# Altium schematic sheet acroiq_basic.SchDoc
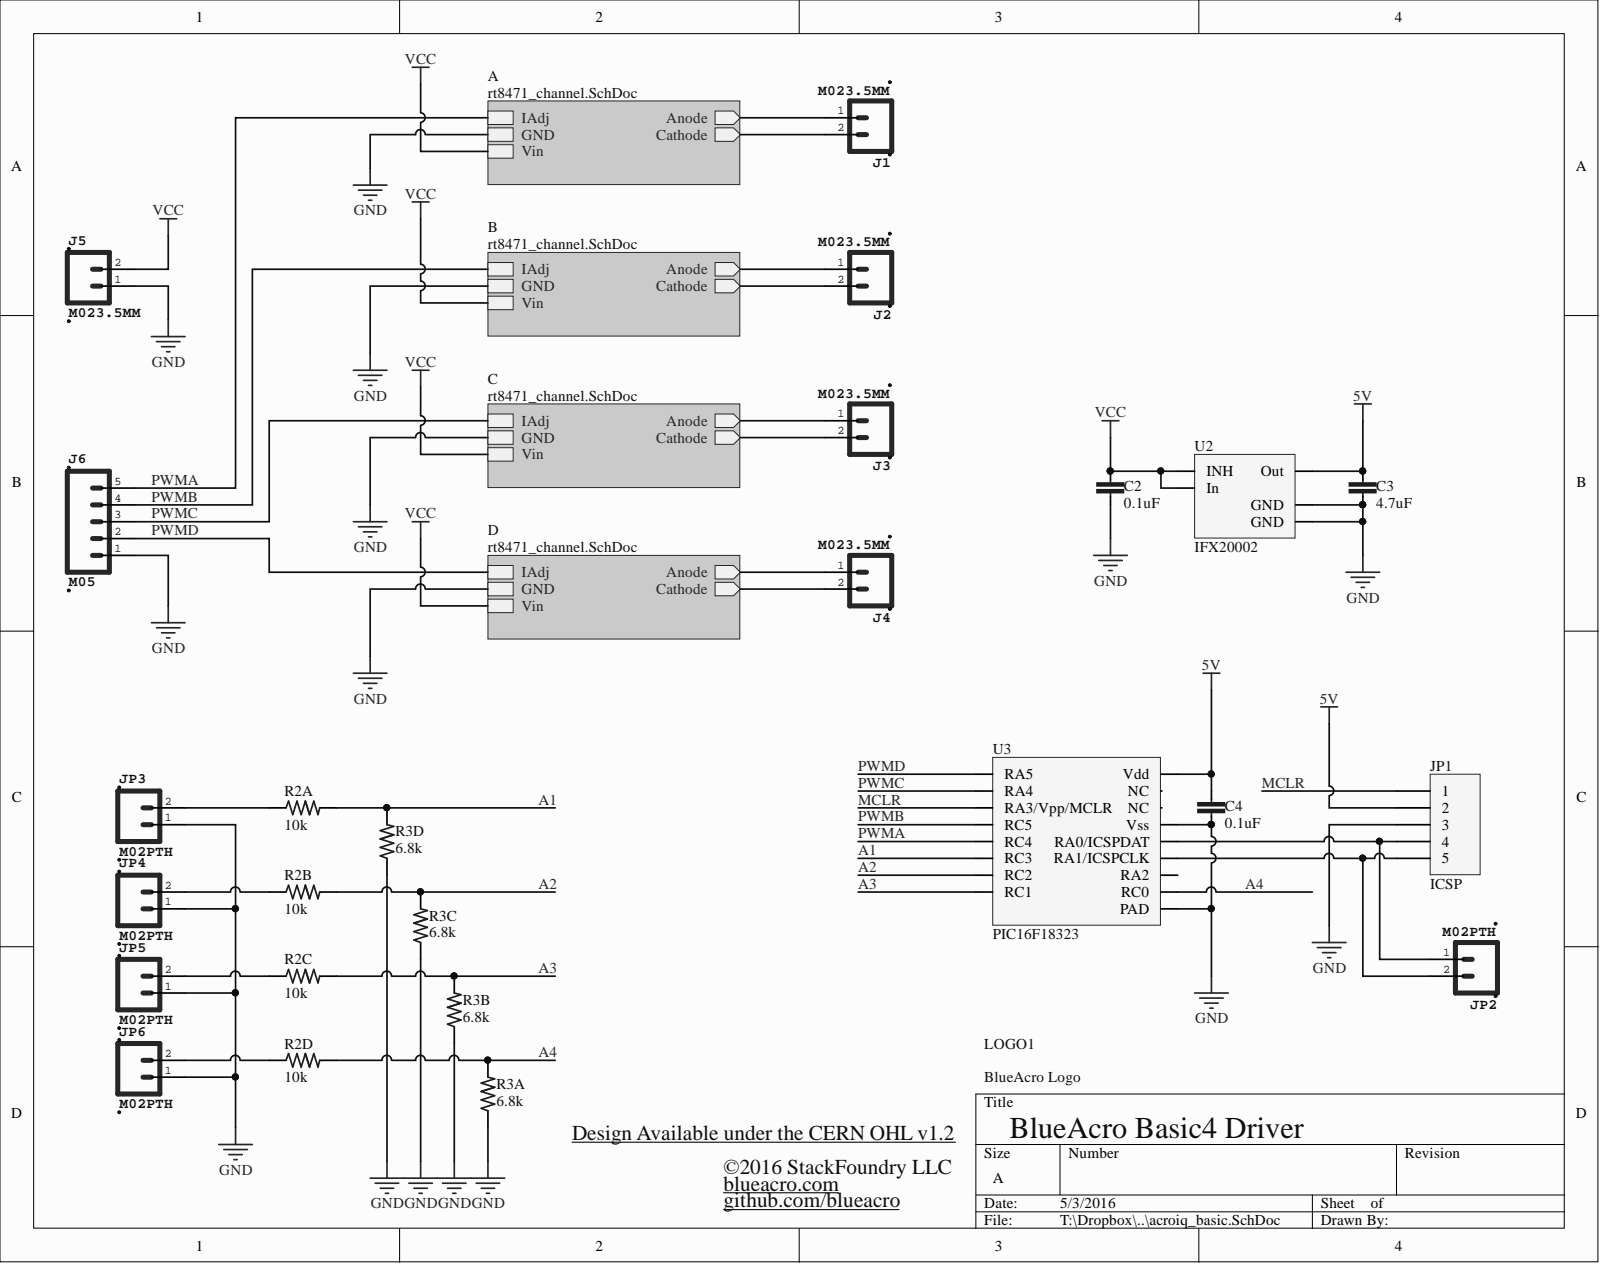
[diagram p0: acroiq_basic.SchDoc]

## Parts
C2  0.1uF  [C0603]
C3  4.7uF  [C0603]
C4  0.1uF  [C0603]
J1  M023.5MM  [SCREWTERMINAL-3.5MM-2]
J2  M023.5MM  [SCREWTERMINAL-3.5MM-2]
J3  M023.5MM  [SCREWTERMINAL-3.5MM-2]
J4  M023.5MM  [SCREWTERMINAL-3.5MM-2]
J5  M023.5MM  [SCREWTERMINAL-3.5MM-2]
J6  M05  [1X05]
JP1  ICSP  [TC2030-MCP-NL]
JP2  M02PTH  [1X02]
JP3  M02PTH  [1X02]
JP4  M02PTH  [1X02]
JP5  M02PTH  [1X02]
JP6  M02PTH  [1X02]
LOGO1  BlueAcro Logo  [BLUEACRO_LOGO_500MIL]
R2  10k  [R0402x4]  (parts A,B,C,D)
R3  6.8k  [R0402x4]  (parts A,B,C,D)
U2  IFX20002  [PG-SCT595-5]
U3  PIC16F18323  [QFN16]

## Nets
5V: C3.1 C4.1 JP1.2 U2.4(Out) U3.16(Vdd)
GND: C2.2 C3.2 C4.2 J5.1 J6.1 JP1.3 JP3.1 JP4.1 JP5.1 JP6.1 R3.1 R3.3 R3.5 R3.7 U2.2(GND) U2.5(GND) U3.13(Vss) U3.PAD
VCC: C2.1 J5.2 U2.1(INH) U2.3(In)
A1: R2.2 R3.8 U3.6(RC3)
A2: R2.4 R3.6 U3.7(RC2)
A3: R2.6 R3.4 U3.8(RC1)
A4: R2.8 R3.2 U3.9(RC0)
MCLR: JP1.1 U3.3(RA3/Vpp/MCLR)
PWMA: J6.5 U3.5(RC4)
PWMB: J6.4 U3.4(RC5)
PWMC: J6.3 U3.2(RA4)
PWMD: J6.2 U3.1(RA5)
NetJ1_1: J1.1
NetJ1_2: J1.2
NetJ2_1: J2.1
NetJ2_2: J2.2
NetJ3_1: J3.1
NetJ3_2: J3.2
NetJ4_1: J4.1
NetJ4_2: J4.2
NetJP1_4: JP1.4 JP2.1 U3.12(RA0/ICSPDAT)
NetJP1_5: JP1.5 JP2.2 U3.11(RA1/ICSPCLK)
NetJP3_2: JP3.2 R2.1
NetJP4_2: JP4.2 R2.3
NetJP5_2: JP5.2 R2.5
NetJP6_2: JP6.2 R2.7
NetU3_10: U3.10(RA2)
NetU3_14: U3.14
NetU3_15: U3.15

## Library data of the parts
C2-C4 = C-EU: Component Kind: Standard; Library Name: rcl.IntLib
R2 R3 = R-US-4ch: Component Kind: Standard; Library Name: rcl.IntLib
J1-J5 = M023.5MM: Component Kind: Standard; Library Name: connectors.IntLib
J6 = M05: Component Kind: Standard; Library Name: connectors.IntLib
JP1 = ICSP: Component Kind: Standard; Library Name: TC2030.IntLib
JP2-JP6 = M02PTH: Component Kind: Standard; Library Name: connectors.IntLib
LOGO1 = BlueAcro Logo: Component Kind: Standard; Library Name: logos.IntLib
U2 = IFX20002: Component Kind: Standard; Library Name: general.IntLib
U3 = PIC16F18323: Component Kind: Standard; Library Name: general.IntLib
